# Altium schematic sheet Si_Chip.SchDoc
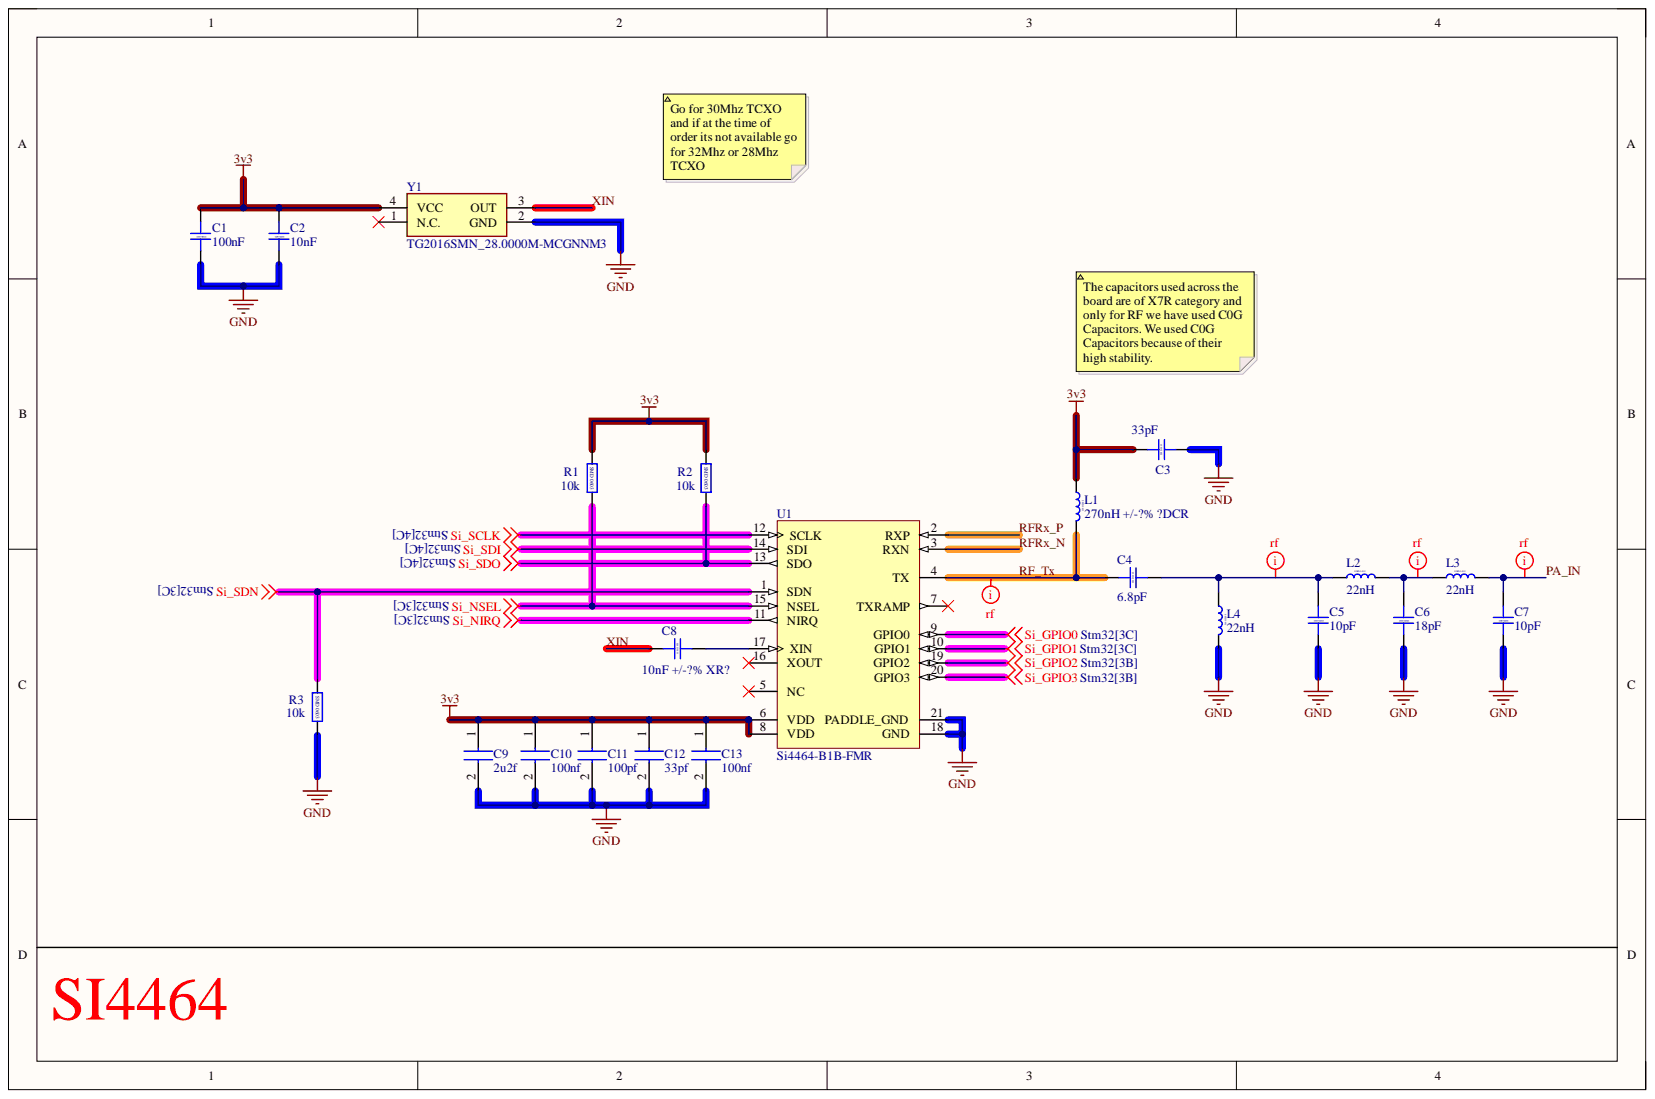
[diagram p0: Si_Chip.SchDoc]

## Parts
C1  100nF  [CAP_0603]
C2  10nF  [CAP_0603]
C3  33pF  [CAP_0603]
C4  6.8pF  [CAP_0402]
C5  10pF  [CAP_0402]
C6  18pF  [CAP_0402]
C7  10pF  [CAP_0402]
C8  10nF +/-?% XR?  [CAP_0603]
C9  2u2f  [CAPC1608X87N]
C10  100nf  [CAPC1608X87N]
C11  100pf  [CAPC1608X87N]
C12  33pf  [CAPC1608X87N]
C13  100nf  [CAPC1608X87N]
L1  270nH +/-?% ?DCR  [IND_0603]
L2  22nH  [IND_0402]
L3  22nH  [IND_0402]
L4  22nH  [IND_0402]
R1  10k  [RES_0603]
R2  10k  [RES_0603]
R3  10k  [RES_0603]
U1  Si4464-B1B-FMR  [SLAB-QFN-20-2600x2600TP_M]
Y1  TG2016SMN_28.0000M-MCGNNM3  [TG2016SMN250000MMCGNNM3]

## Nets
3v3: C1.2 C2.2 C3.1 C9.1 C10.1 C11.1 C12.1 C13.1 L1.1 R1.1 R2.1 U1.6(VDD) U1.8(VDD) Y1.4(VCC)
GND: C1.1 C2.1 C3.2 C5.1 C6.1 C7.1 C9.2 C10.2 C11.2 C12.2 C13.2 L4.2 R3.2 U1.18(GND) U1.21(PADDLE_GND) Y1.2(GND)
Si_GPIO0: U1.9(GPIO0)
Si_GPIO1: U1.10(GPIO1)
Si_GPIO2: U1.19(GPIO2)
Si_GPIO3: U1.20(GPIO3)
Si_NIRQ: U1.11(NIRQ)
Si_NSEL: R1.2 U1.15(NSEL)
Si_SCLK: U1.12(SCLK)
Si_SDI: U1.14(SDI)
Si_SDN: R3.1 U1.1(SDN)
Si_SDO: R2.2 U1.13(SDO)
PA_IN: C7.2 L3.2
RF_Tx: C4.1 L1.2 U1.4(TX)
RFRx_N: U1.3(RXN)
RFRx_P: U1.2(RXP)
XIN: C8.2 Y1.3(OUT)
NetC4_2: C4.2 C5.2 L2.1 L4.1
NetC6_2: C6.2 L2.2 L3.1
NetC8_1: C8.1 U1.17(XIN)

Not connected: U1 5(NC) 7(TXRAMP) 16(XOUT); Y1 1(N.C.)

## Library data of the parts
C1-C3 C8 = Capacitor-SMD-0603: Capacitor SMD 0603 RATED; Component Kind: Standard; Library Name: STeRG-Passives-Standard.SchLib
C4-C7 = Capacitor-SMD-0402: Capacitor SMD 0402 RATED; Component Kind: Standard; Library Name: STeRG-Passives-Standard.SchLib
C9-C13 = 0603N470J500CT: Capacitor; Manufacturer_Name: Walsin Technology Corporation; Component Kind: Standard; Datasheet Link: https://datasheet.datasheetarchive.com/originals/distributors/DKDS41/DSANUWW0027552.pdf; Height: 0.87mm; Library Name: SamacSys.SchLib; Manufacturer_Part_Number: 0603N470J500CT; Mouser Part Number: 791-0603N470J500CT; Mouser Price/Stock: https://www.mouser.co.uk/ProductDetail/Walsin/0603N470J500CT?qs=ZrPdAQfJ6DOWJe9N0xQVbw%3D%3D
L1 = Inductor-SMD-RF-0603: Inductor SMD 0603; Component Kind: Standard; Library Name: STeRG-Passives-Standard.SchLib
L2-L4 = Inductor-SMD-RF-0402: Inductor SMD 0402; Component Kind: Standard; Library Name: STeRG-Passives-Standard.SchLib
R1-R3 = Resistor-SMD-0603: Resistor SMD 0603 RATED; Component Kind: Standard; Library Name: STeRG-Passives-Standard.SchLib
U1 = CMP-0520-00036-1: High-Performance, Low-Current Transceiver, 119 to 960 MHz, -20 to 20 dBm Output Power, -40 to 85 degC, 20-pin QFN, Tape and Reel; Manufacturer: Silicon Labs; FSK/GFSK: 500 kbps; OOK: 120 kbps; RX Current: 10.6/13.6 mA; Sub-Family: EZRadioPRO; Code_IPC: J-STD-020C; Code_JEDEC: MO-220; Component Kind: Standard; ComponentLink1Description: Manufacturer URL; ComponentLink1URL: http://www.silabs.com/; ComponentLink2Description: Datasheet; ComponentLink2URL: http://www.silabs.com/Support Documents/TechnicalDocs/Si4464-63-61-60.pdf; ComponentLink3Description: Package Specification; ComponentLink3URL: http://www.silabs.com/Support%20Documents/TechnicalDocs/Si4464-63-61-60.pdf; DatasheetVersion: Rev. 1.2; Frequency Range: 119 to 960 MHz; Library Name: Altium Content Vault; Mounting Technology: Surface Mount; Output Power Range: -20 to 20 dBm; PackageDescription: 20-Pin Quad-Flat No-Leads Package, Body 4 x 4 mm, Pitch 0.5 mm; PackageReference: QFN-20; Packing: Tape and Reel; PartNumber: Si4464-B1B-FMR
Y1 = TG2016SMN_25.0000M-MCGNNM3: Crystal or Oscillator; Manufacturer_Name: Epson Timing; Manufacturer_Part_Number: TG2016SMN 25.0000M-MCGNNM3; Arrow Part Number: TG2016SMN 25.0000M-MCGNNM3; Arrow Price/Stock: https://www.arrow.com/en/products/tg2016smn-25.0000m-mcgnnm3/epson-electronics-america?region=nac; Component Kind: Standard; Datasheet Link: https://support.epson.biz/td/api/doc_check.php?dl=app_TG2016SMN&lang=en; Height: 0.73mm; Library Name: SamacSys.SchLib; Mouser Part Number: 732-TG216S25MCGNNM3; Mouser Price/Stock: https://www.mouser.co.uk/ProductDetail/Epson-Timing/TG2016SMN-25.0000M-MCGNNM3?qs=d0WKAl%252BL4Ka73EeK48LHvA%3D%3D
